# Altium schematic sheet Full Design.SchDoc
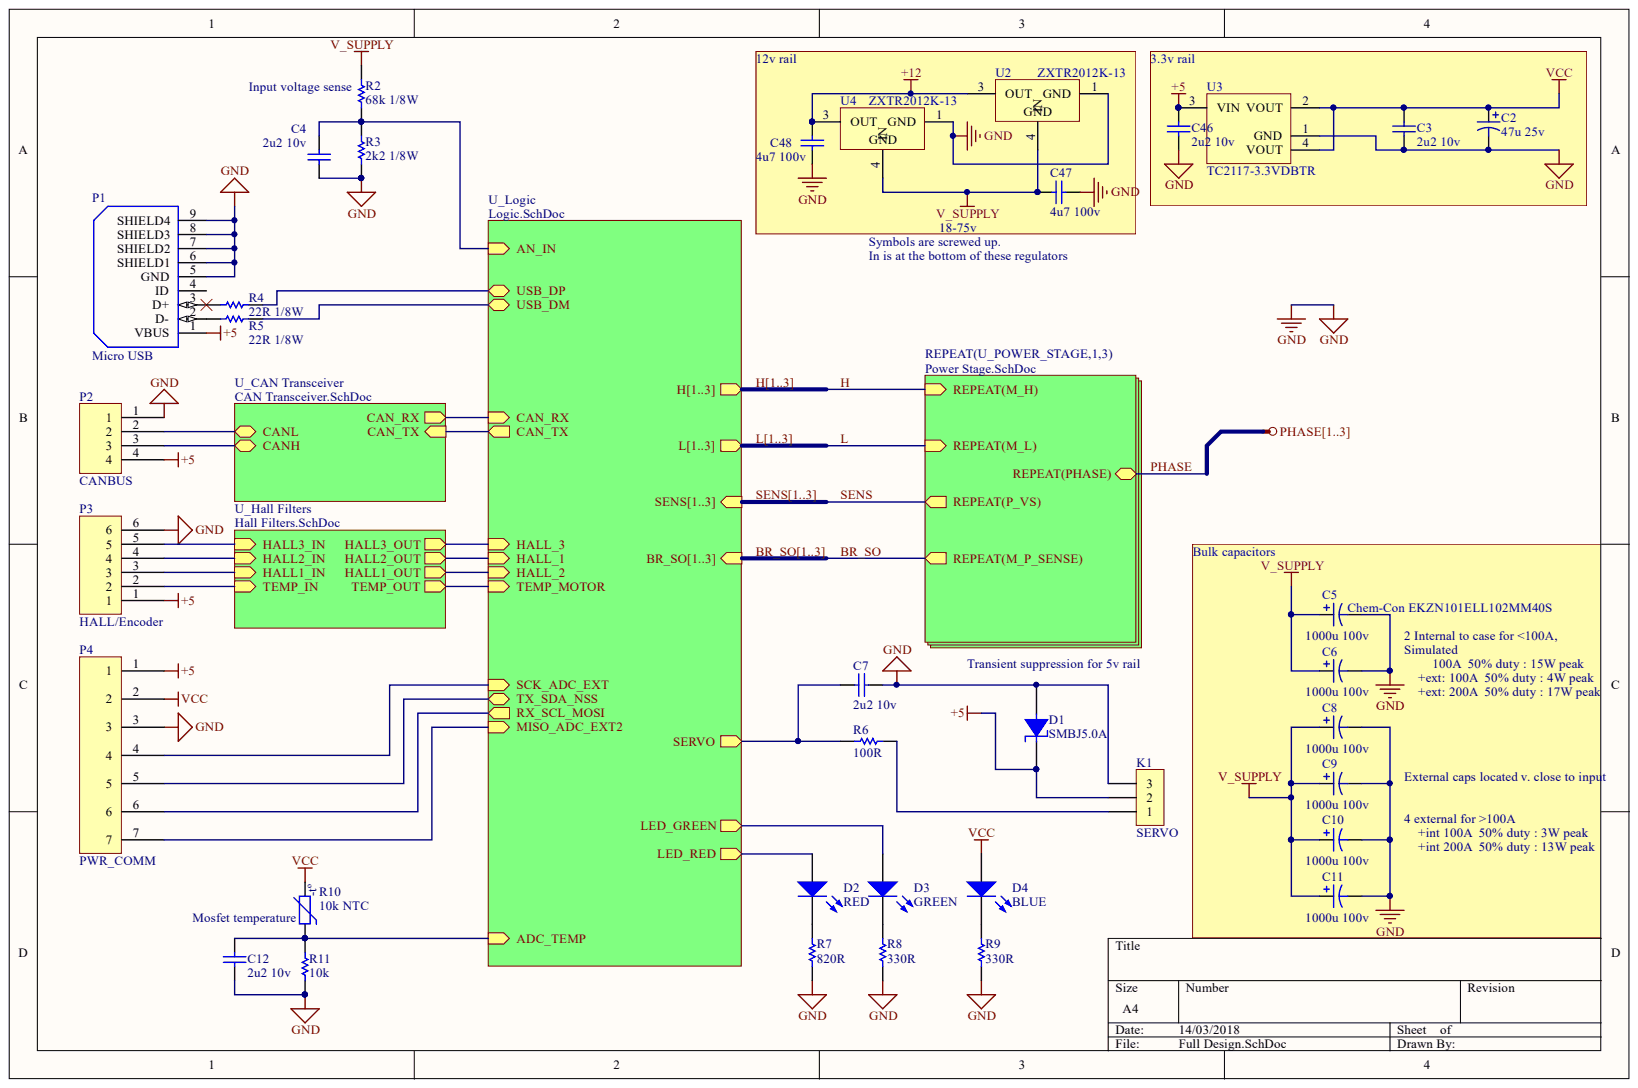
[diagram p0: Full Design.SchDoc]

## Parts
C2  47u 25v  [PCB-ttmloswgb6etwexoq0om-1]
C3  2u2 10v  [CAPC2013X140X45LL15T25]
C4  2u2 10v  [CAPC2013X145X48LL20T23]
C5  Chem-Con EKZN101ELL102MM40S  [PCB-92350-1]
C6  Nihicon UPW2A102MHD  [PCB-92350-1]  (value not drawn)
C7  2u2 10v  [CAPC2013X145X48LL20T23]
C8  Nihicon UPW2A102MHD  [PCB-92350-1]  (value not drawn)
C9  Nihicon UPW2A102MHD  [PCB-92350-1]  (value not drawn)
C10  Nihicon UPW2A102MHD  [PCB-92350-1]  (value not drawn)
C11  Nihicon UPW2A102MHD  [PCB-92350-1]  (value not drawn)
C12  2u2 10v  [CAPC2013X140X45LL15T25]
C46  2u2 10v  [CAPC2013X140X45LL15T25]
C47  4u7 100v  [CAPC3216X190X60LL30T20]
C48  4u7 100v  [CAPC3216X190X60LL30T20]
D1  SMBJ5.0A  [PCB-s2hb2ch5vg041xcosymn-1]
D2  RED  [PCB-owstrhobcfeir1dfk2na-1]
D3  GREEN  [PCB-owstrhobcfeir1dfk2na-1]
D4  BLUE  [PCB-owstrhobcfeir1dfk2na-1]
K1  SERVO  [PCB-j8j18jq6r1co3hhs2ej2-1]
P1  Micro USB  [FCI-USB-4SHLD_AP8604]
P2  CANBUS  [PCB-gpdi66yn1jko56crxrq7-1]
P3  HALL/Encoder  [PCB-4512572-1]
P4  PWR_COMM  [PCB-5xtqpwx7u0homgs4st3y-1]
R2  68k 1/8W  [RESC2013X50X30LL20T20]
R3  2k2 1/8W  [RESC2013X70X40ML20T20]
R4  22R 1/8W  [R0805_B]
R5  22R 1/8W  [R0805_B]
R6  100R  [RESC2013X70X40ML20T20]
R7  820R  [RESC2013X50X30ML20T20]
R8  330R  [RESC2013X50X30ML20T20]
R9  330R  [RESC2013X70X40ML20T20]
R10  10k NTC  [PCB-2153949-1]
R11  10k  [RESC2012X05N]
U2  ZXTR2012K-13  [TD03B_L]
U3  TC2117-3.3VDBTR  [SOT-223-DB3_L]
U4  ZXTR2012K-13  [TD03B_L]

## Nets
+5: C46.2 D1.1 K1.2 P1.1(VBUS) P2.4 P3.1 P4.1 U3.3(VIN)
+12: C48.1 U2.3 U4.3
GND: C2.2 C3.1 C4.1 C5.2 C6.2 C7.1 C8.2 C9.2 C10.2 C11.2 C12.1 C46.1 C47.1 C48.2 D1.2 K1.3 P1.5(GND) P1.6(SHIELD1) P1.7(SHIELD2) P1.8(SHIELD3) P1.9(SHIELD4) P2.1 P3.6 P4.3 R3.1 R7.1 R8.1 R9.2 R11.1 U2.1 U3.1(GND) U4.1
V_SUPPLY: C5.1 C6.1 C8.1 C9.1 C10.1 C11.1 C47.2 R2.2 U2.4 U4.4
VCC: C2.1 C3.2 D4.1 P4.2 R10.2 U3.2(VOUT) U3.4(VOUT)
NetC4_2: C4.2 R2.1 R3.2
NetC7_2: C7.2 R6.2
NetC12_2: C12.2 R10.1 R11.2
NetD2_1: D2.1
NetD2_2: D2.2 R7.2
NetD3_1: D3.1
NetD3_2: D3.2 R8.2
NetD4_2: D4.2 R9.1
NetK1_1: K1.1 R6.1
NetP1_2: P1.2(D-) R5.1
NetP1_3: P1.3(D+) R4.1
NetP1_4: P1.4(ID)
NetP2_2: P2.2
NetP2_3: P2.3
NetP3_2: P3.2
NetP3_3: P3.3
NetP3_4: P3.4
NetP3_5: P3.5
NetP4_4: P4.4
NetP4_5: P4.5
NetP4_6: P4.6
NetP4_7: P4.7
NetR4_2: R4.2
NetR5_2: R5.2
BR_SO1:  [also labelled BR_SO]
BR_SO2:  [also labelled BR_SO]
BR_SO3:  [also labelled BR_SO]
CAN_RX:
CAN_TX:
H1:  [also labelled H]
H2:  [also labelled H]
H3:  [also labelled H]
HALL1_OUT:
HALL2_OUT:
HALL3_OUT:
L1:  [also labelled L]
L2:  [also labelled L]
L3:  [also labelled L]
PHASE1:
PHASE2:
PHASE3:
SENS1:  [also labelled SENS]
SENS2:  [also labelled SENS]
SENS3:  [also labelled SENS]
TEMP_OUT:

## Library data of the parts
C2 = TR3D107K016C0075: Cap, Tant, 100uf, 16v, Case d, Reel; Capacitance: 100µF; Capacitance Tolerance: ±10%; Voltage Rating (DC): 16.0 V; Component Kind: Standard; Library Name: Octopart library; Manufacturer Part Number: TR3D107K016C0075; OctopartID: 57819c2e8dbe8846; Octopart URL: http://octopart.com/tr3d107k016c0075-sprague-44433241; RoHS: Compliant
C3 C12 C46 = CMP-121336-2: CAP CER 2.2UF 16V 10% X7R 0805; Capacitance: 2.2e-06 F; Lead-Free Status: Lead Free; Voltage Rating (DC): 16.0 V; Capacitance Tolerance: ±10%; Case/Package: 0805; Component Kind: Standard; Dielectric Characteristic: X7R; Library Name: CommunityVault; OctopartID: 8b52429a318f5495; Octopart Url: https://octopart.com/gcm21br71c225ka64l-murata-12754875; RoHS: Compliant
C4 = VJ0805Y104KXAAT: Cap Ceramic 0.1uF 50V X7R 10% SMD 0805 150C Plastic T/R; Capacitance: 1e-07 F; Case/Package (SI): 2012; Dielectric Material: Ceramic Multilayer; Lead-Free Status: Lead Free; Voltage Rating (DC): 50.0 V; Capacitance Tolerance: ±10%; Case/Package: 0805; Component Kind: Standard; Dielectric Characteristic: X7R; Library Name: Octopart library; Mounting Style: Surface Mount; Number of Pins: 2; OctopartID: 165bdd0c9fd7c99c; Octopart Url: https://octopart.com/vj0805y104kxaat-vishay-39693546; Packaging: Cut Tape (CT); RoHS: Compliant
C5 C6 C8-C11 = CMP-92350-4: CAP ALUM 1000UF 100V 20% RADIAL; Capacitance: 0.001 F; Dielectric Material: Aluminum Electrolytic; Equivalent Series Resistance (ESR): 0.094 Ω; Lead-Free Status: Lead Free; Voltage Rating (DC): 100.0 V; Capacitance Tolerance: ±20%; Component Kind: Standard; Library Name: CommunityVault; Mounting Style: Through Hole; OctopartID: 75bfbcb6482aaa78; Octopart Url: https://octopart.com/upw2a102mhd-nichicon-202995; Packaging: Bulk; RoHS: Compliant; Size-Diameter: 0.018 m; Size-Height: 0.04 m; Size-Length: 0.04 m
C7 = CMP-153474-2: Cap Ceramic 0.1uF 50V X7R 10% SMD 0805 150C Plastic T/R; Capacitance: 1e-07 F; Case/Package (SI): 2012; Dielectric Material: Ceramic Multilayer; Lead-Free Status: Lead Free; Voltage Rating (DC): 50.0 V; Capacitance Tolerance: ±10%; Case/Package: 0805; Component Kind: Standard; Dielectric Characteristic: X7R; Library Name: CommunityVault; Mounting Style: Surface Mount; Number of Pins: 2; OctopartID: 165bdd0c9fd7c99c; Octopart Url: https://octopart.com/vj0805y104kxaat-vishay-39693546; Packaging: Cut Tape (CT); RoHS: Compliant
C47 C48 = VJ1206Y104JXBTW1BC: Multilayer Ceramic Capacitors MLCC - SMD/SMT 1206 0.1uF 100volts X7R 5%; Capacitance: 1e-07 F; Dielectric Material: Tantalum; Lead-Free Status: Lead Free; Voltage Rating (DC): 100.0 V; Capacitance Tolerance: ±5%; Case/Package: 1206; Component Kind: Standard; Dielectric Characteristic: X7R; Library Name: Octopart library; OctopartID: ff4334c2f3612084; Octopart Url: https://octopart.com/vj1206y104jxbtw1bc-vishay-42674500; RoHS: Compliant
D1 = SMBJ5.0A: Transient Voltage Suppressor, TVS, SMBJ Series, Unidirectional, 5 V, DO-214AA, 2, 6.4 V; Lead-Free Status: Lead Free; Operating Voltage: 5.00 V; Power Rating: 600 W; Case/Package: DO-214; Component Kind: Standard; Library Name: Octopart library; Manufacturer Part Number: SMBJ5.0A; Mounting Style: Surface Mount; Number of Pins: 2; OctopartID: c81601df987f17d1; Octopart URL: http://octopart.com/smbj5.0a-bourns-12809487; Packaging: Cut Tape (CT); RoHS: Compliant; Size-Height: 2.44 mm; Size-Length: 5.59 mm; Voltage Rating (DC): 5.00 V
D2-D4 = HSMG-C170: LED; SMD; 0805; green; 4.5-15mcd; 2x1.25x0.8mm; 170°; 2.2÷2.6V; 20mA; Lead-Free Status: Lead Free; Luminous Intensity: 15.0 mcd; Component Kind: Standard; Library Name: Octopart library; Mounting Style: Surface Mount; Packaging: Cut Tape (CT); Viewing Angle: 170 °
K1 = CMP-0f4fc6c899cbd088-1: Single Row 3 Position GOLD FLASH .235" Solder TAIL .100" PIN Header; Manufacturer Part Number: PH1-03-UA; Lead-Free Status: Lead Free; Component Kind: Standard; Library Name: CommunityVault; OctopartID: 0f4fc6c899cbd088; Octopart URL: http://octopart.com/ph1-03-ua-adam+tech-9286626; RoHS: Compliant
P1 = CMP-c7b40fa1d6b01873-6: Conn USB Type A/B RCP 5 POS 0.65mm Solder RA SMD 5 Terminal 1 Port T/R; Manufacturer Part Number: 10104111-0001LF; Gender: Female; Number of Contacts: 5; Component Kind: Standard; Contact Material: Copper Alloy; Contact Plating: Gold; Library Name: CommunityVault; Mounting Style: Surface Mount; OctopartID: c7b40fa1d6b01873; Octopart Url: http://octopart.com/10104111-0001lf-fci-18822242; Orientation: Right Angle; REACH SVHC Compliance: No SVHC; RoHS: Compliant; Seller Landing  Url: https://octopart-clicks.com/click/track?country=US&ak=8157f166&sig=0e93c97&sid=5489&ppid=18822242&vpid=43983423&ct=offers
P2 = CMP-642981-12: PH Series 4 Position 2 mm Pitch Through Hole Crimp Top Entry Shrouded Header; Manufacturer Part Number: B4B-PH-K-S(LF)(SN); Contact Resistance: 10.0 mΩ; Current Rating: 2.00 A; Gender: Male; Insulation Resistance: 1.00 MΩ; Lead-Free Status: Lead Free; Number of Contacts: 4; Pin Pitch: 2.00 mm; Voltage Rating (AC): 100 V; Component Kind: Standard; Contact Material: Brass; Contact Plating: Tin; Housing Color: Natural; Housing Material: Nylon; Library Name: CommunityVault; Mounting Style: Through Hole, Vertical; Number of Positions: 4; Number of Rows: 1; OctopartID: 98b351ba71f34774; Octopart URL: http://octopart.com/b4b-ph-k-s%28lf%29%28sn%29-jst-248870; Packaging: Bulk; REACH SVHC Compliance: No SVHC; RoHS: Compliant; Size-Height: 6.00 mm; Size-Length: 9.90 mm; Size-Width: 4.50 mm
P3 = CMP-4512572-2: CONN HEADER PH TOP 6POS 2MM; Gender: Male; Lead-Free Status: Contains Lead; Number of Contacts: 6; Pin Pitch: 0.002 m; Component Kind: Standard; Contact Material: Brass; Contact Plating: Tin Lead; Housing Color: Natural; Housing Material: Nylon; Library Name: CommunityVault; Mounting Style: Through Hole; Number of Positions: 6; Number of Rows: 1; OctopartID: dbb912b035ad047e; Octopart Url: https://octopart.com/b6b-ph-k-s-jst-248690; Orientation: Vertical; Packaging: Bulk; RoHS: Non-Compliant; Size-Height: 0.006 m; Size-Length: 0.0139 m; Size-Width: 0.0045 m
P4 = B7B-PH-K-S(LF)(SN): JST B7B-PH-K-S (LF)(SN) Multi-pin Connector, Straight Series PH Number of pins: 7 Nominal current: 2 A; Voltage Rating (AC): 100 V; Component Kind: Standard; Contact Plating: Tin; Library Name: Octopart library; Manufacturer: JST; Size-Width: 4.50 mm
R2 = CRCW080568K0FKEA: RES SMD 68K OHM 1% 1/8W 0805; Case/Package (SI): 2012; Lead-Free Status: Lead Free; Power Rating: 0.125 W; Resistance: 68000.0 Ω; Voltage Rating (DC): 150.0 V; Case/Package: 0805; Component Kind: Standard; Library Name: Octopart library; Mounting Style: Surface Mount; Number of Pins: 2; OctopartID: 585793029aeb8790; Octopart Url: https://octopart.com/crcw080568k0fkea-vishay-39839706; REACH SVHC Compliance: No SVHC; Resistance Tolerance: ±1%; RoHS: Compliant; Size-Height: 0.00045 m; Size-Length: 0.002 m; Size-Width: 0.00125 m
R3 R6 R9 = ERJ6ENF2201V: Res Thick Film 0805 2.2K Ohm 1% 0.125W(1/8W) ?100ppm/C Molded SMD Automotive T/R; Component Kind: Standard; Library Name: Octopart library
R4 R5 = RC0805JR-0722RL: Surface Mount Thick Film Resistor, RC Series, 22 ohm, 125 mW, - 5%, 150 V, 0805 [2012 Metric]; Case/Package (SI): 2012; Lead-Free Status: Lead Free; Power Rating: 0.125 W; Resistance: 22.0 Ω; Voltage Rating (DC): 150.0 V; Case/Package: 0805; Component Kind: Standard; Library Name: Octopart library; Manufacturer Part Number: RC0805JR-0722RL; Mounting Style: Surface Mount; Number of Pins: 2; OctopartID: fd9a36f21111225d; Octopart Url: http://octopart.com/rc0805jr-0722rl-yageo-40028653; Packaging: Cut Tape (CT); REACH SVHC Compliance: No SVHC; Resistance Tolerance: ±5%; RoHS: Compliant; Seller Landing  Url: https://octopart-clicks.com/click/track?country=US&ak=8157f166&sig=0120450&sid=5489&ppid=40028653&vpid=192037875&ct=offers; Size-Height: 0.0005 m; Size-Length: 0.002 m; Size-Width: 0.00125 m
R7 R8 = CRCW0805100RFKEAHP: Res Thick Film 0805 100 Ohm 1% 1/3W Â±100ppm/Â°C Molded SMD SMD Paper T/R; Case/Package (SI): 2012; Power Rating: 125 mW; Resistance: 100 Ω; Case/Package: 0805; Component Kind: Standard; Library Name: Octopart library; Manufacturer Part Number: CRCW0805100RFKEAHP; Mounting Style: Surface Mount; Octopart URL: http://octopart.com/crcw0805100rfkeahp-vishay-42634543; REACH SVHC Compliance: No SVHC; Resistance Tolerance: ±1%; RoHS: Compliant
R10 = NCP21XV103J03RA: THERMISTOR 10K OHM NTC 0805 SMD; Case/Package (SI): 2012; Lead-Free Status: Lead Free; Resistance: 10000.0 Ω; Resistance Tolerance: ±5%; Case/Package: 0805; Component Kind: Standard; Library Name: Octopart library; Mounting Style: Surface Mount; Number of Pins: 2; OctopartID: a8586159edc6779f; Octopart Url: https://octopart.com/ncp21xv103j03ra-murata-745720; REACH SVHC Compliance: No SVHC; RoHS: Compliant; Size-Length: 0.002 m; Size-Thickness: 0.00015 m; Size-Width: 0.00125 m
R11 = CRCW080510K0JNEA: Res Thick Film 0805 10K Ohm 5% 0.125W(1/8W) ±200ppm/C Molded SMD Automotive Paper T/R; Case/Package (SI): 2012; Lead-Free Status: Lead Free; Power Rating: 125 mW; Resistance: 10.0 kΩ; Temperature Coefficient: 200 ppm/°C; Voltage Rating (DC): 150 V; Case/Package: 0805; Component Kind: Standard; Library Name: Octopart library; Manufacturer Part Number: CRCW080510K0JNEA; Mounting Style: Surface Mount; Number of Pins: 2; OctopartID: d1941ac4fd730e53; Octopart URL: http://octopart.com/crcw080510k0jnea-vishay-39455105; Packaging: Cut Tape (CT); REACH SVHC Compliance: No SVHC; Resistance Tolerance: ±5%; RoHS: Compliant; Size-Height: 450 µm; Size-Length: 2.00 mm; Size-Width: 1.25 mm
U2 U4 = CMP-1248815-3: 1A Low Dropout CMOS Linear Regulators Stable with Ceramic Output Capacitors, 3-pin TO-252, Pb-Free; Dropout Voltage: 0.65 V; Lead-Free Status: Lead Free; Number of Regulated Outputs: 1; Output Current: 1.0 A; Output Voltage: 3.3 V; Quiescent Current: 5.5e-05 A; Component Kind: Standard; Library Name: CommunityVault; Lifecycle Status: Active; Mounting Style: Surface Mount; Number of Pins: 3; OctopartID: 5aa392d3d4408564; Octopart Url: https://octopart.com/lp38690dt-3.3%2Fnopb-texas+instruments-24816517; Output Capacitor Type: Ceramic; RoHS: Compliant
U3 = TC2117-3.3VDBTR: 800 mA Fixed Low Dropout Positive Regulator, 3-Pin SOT-223, Extended Temperature, Tape and Reel; Dropout Voltage: 0.45 V; Lead-Free Status: Lead Free; Number of Outputs: 1; Output Capacitor Type: Tantalum; Quiescent Current: 8e-05 A; Case/Package: SOT-223; Component Kind: Standard; Library Name: Octopart library; Lifecycle Status: Active; Mounting Style: Surface Mount; Number of Pins: 3; OctopartID: 29dfe0dd4c37f618; Octopart Url: https://octopart.com/tc2117-3.3vdbtr-microchip-540814; REACH SVHC Compliance: No SVHC; RoHS: Compliant
